# Altium schematic sheet esp32-board.SchDoc
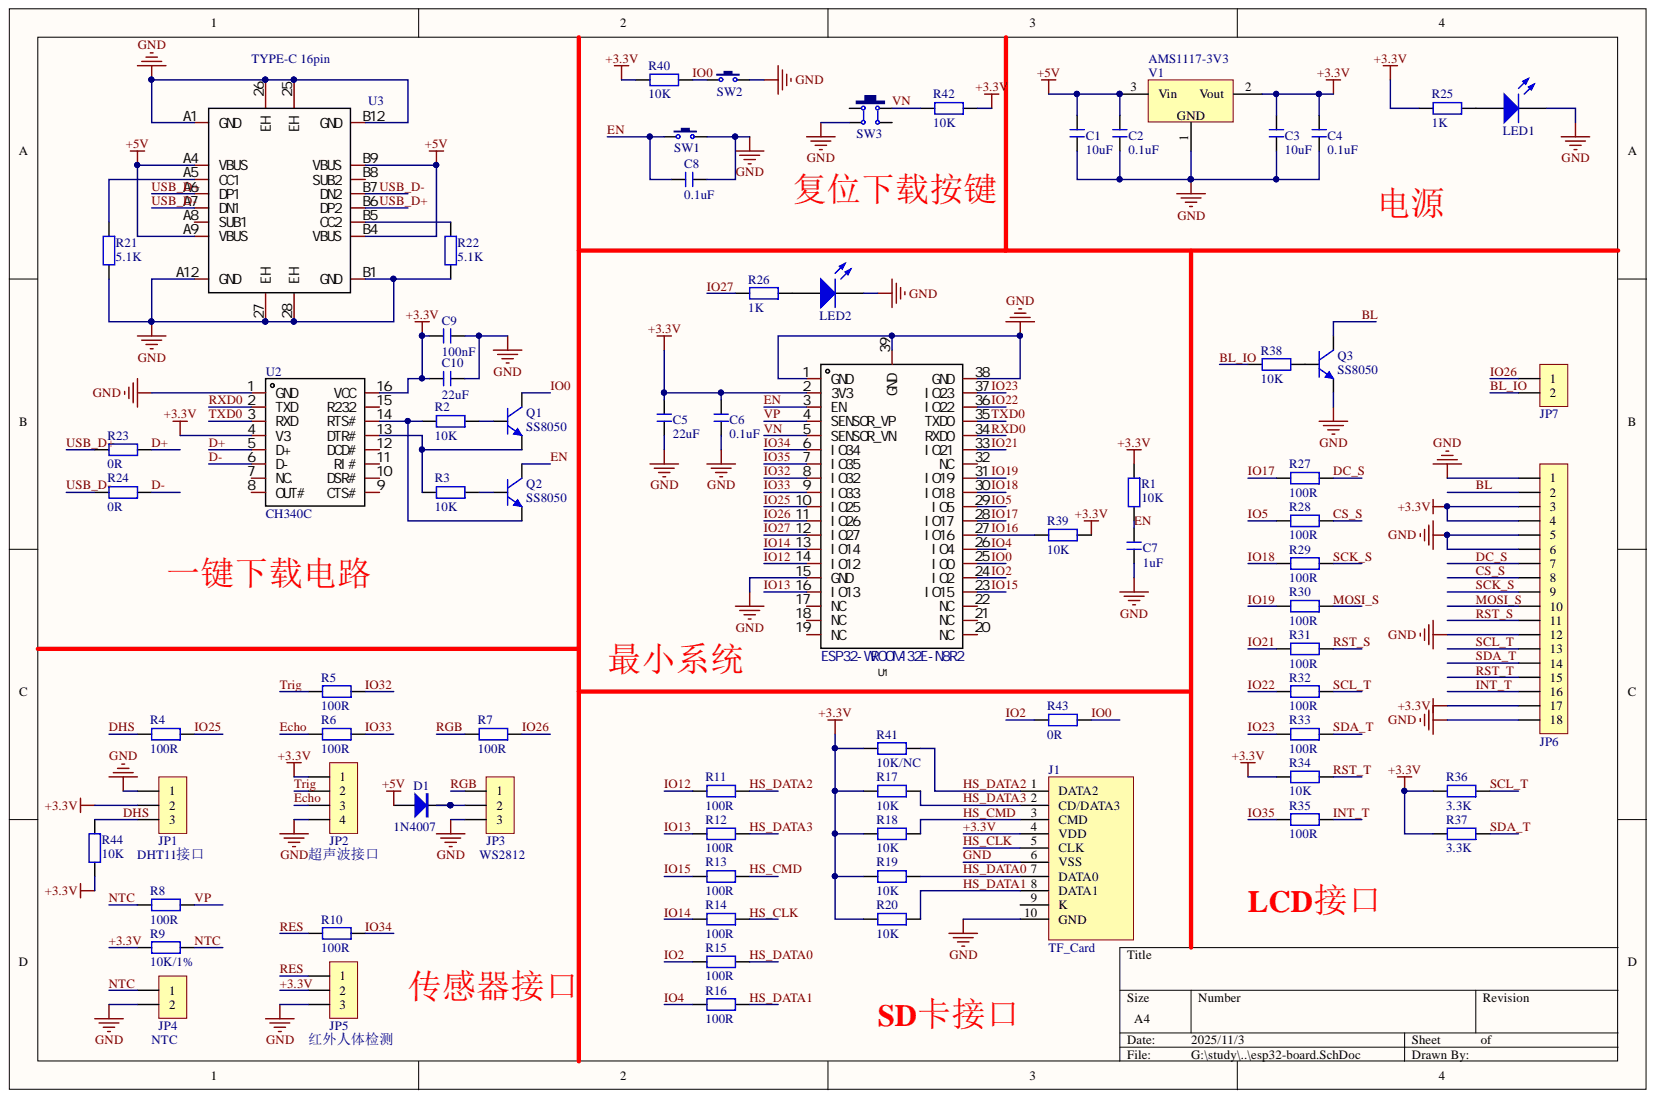
[diagram p0: esp32-board.SchDoc]

## Parts
C1  10uF  [C 0402_M]
C2  0.1uF  [C 0402_M]
C3  10uF  [C 0402_M]
C4  0.1uF  [C 0402_M]
C5  22uF  [C 0402_M]
C6  0.1uF  [C 0402_M]
C7  1uF  [C 0402_M]
C8  0.1uF  [C 0402_M]
C9  100nF  [C 0402_M]
C10  22uF  [C 0402_M]
D1  1N4007  [SOD-123]
J1  TF_Card  [Micro SD]
JP1  HDR-1X3  [HDR2.54-LI-3P]  (value not drawn)
JP2  HDR-1X4  [HDR2.54-LI-4P]  (value not drawn)
JP3  HDR-1X3  [HDR2.54-LI-3P]  (value not drawn)
JP4  HDR-1X2  [XH2.54-WI-2P]  (value not drawn)
JP5  HDR-1X3  [HDR2.54-LI-3P]  (value not drawn)
JP6  FPC0.5-18P 上接  [FPC0.5 2H-WS-18P]  (value not drawn)
JP7  HDR-1X2  [HDR2.54-LI-2P]  (value not drawn)
LED1  LED  [LED 0603R]
LED2  LED  [LED 0603B]
Q1  SS8050  [SOT23-3L]
Q2  SS8050  [SOT23-3L]
Q3  SS8050  [SOT23-3N]
R1  10K  [R 0402_L]
R2  10K  [R 0402_L]
R3  10K  [R 0402_L]
R4  100R  [R 0402_L]
R5  100R  [R 0402_L]
R6  100R  [R 0402_L]
R7  100R  [R 0402_L]
R8  100R  [R 0402_L]
R9  10K/1%  [R 0603_L]
R10  100R  [R 0402_L]
R11  100R  [R 0402_L]
R12  100R  [R 0402_L]
R13  100R  [R 0402_L]
R14  100R  [R 0402_L]
R15  100R  [R 0402_L]
R16  100R  [R 0402_L]
R17  10K  [R 0402_L]
R18  10K  [R 0402_L]
R19  10K  [R 0402_L]
R20  10K  [R 0402_L]
R21  5.1K  [R 0402_L]
R22  5.1K  [R 0402_L]
R23  0R  [R 0402_L]
R24  0R  [R 0402_L]
R25  1K  [R 0402_L]
R26  1K  [R 0402_L]
R27  100R  [R 0402_L]
R28  100R  [R 0402_L]
R29  100R  [R 0402_L]
R30  100R  [R 0402_L]
R31  100R  [R 0402_L]
R32  100R  [R 0402_L]
R33  100R  [R 0402_L]
R34  10K  [R 0402_L]
R35  100R  [R 0402_L]
R36  3.3K  [R 0402_L]
R37  3.3K  [R 0402_L]
R38  10K  [R 0402_L]
R39  10K  [R 0402_L]
R40  10K  [R 0402_L]
R41  10K/NC  [R 0402_L]
R42  10K  [R 0402_L]
R43  0R  [R 0402_L]
R44  10K  [R 0402_L]
SW1  3x6x5  [TSW SMD-3*6*5]  (value not drawn)
SW2  3x6x5  [TSW SMD-3*6*5]  (value not drawn)
SW3  6x6x5  [TSW SMD-6*6*5]  (value not drawn)
U1  ESP32-WROOM-32E-N8R2  [WIFI-SMD_ESP32-WROOM-32E]
U2  CH340C  [SOP-16_L10.0-W3.9-P1.27-LS6.0-BL]
U3  TYPE-C 16pin  [USB Type-C-16Pin_A]
V1  AMS1117-3V3  [SOT223_L]

## Nets
+5V: C1.2 C2.2 D1.1 U3.A4(VBUS) U3.A9(VBUS) U3.B4(VBUS) U3.B9(VBUS) V1.3
+3.3V: C3.2 C4.2 C5.2 C6.2 C9.1 C10.1 J1.4(VDD) JP1.2 JP2.1 JP5.2 JP6.3 JP6.4 JP6.17 R1.2 R9.1 R17.1 R18.1 R19.1 R20.1 R25.1 R34.1 R36.1 R37.1 R39.2 R40.1 R41.1 R42.2 R44.1 U1.2(3V3) U2.4(V3) U2.16(VCC) V1.2
BL: JP6.2 Q3.3
BL_IO: JP7.2 R38.1
CS_S: JP6.8 R28.2
D+: R23.1 U2.5(D+)
D-: R24.1 U2.6(D-)
DC_S: JP6.7 R27.2
DHS: JP1.3 R4.1 R44.2
Echo: JP2.3 R6.1
EN: C7.2 C8.2 Q2.3 R1.1 SW1.1 U1.3(EN)
GND: C1.1 C2.1 C3.1 C4.1 C5.1 C6.1 C7.1 C8.1 C9.2 C10.2 J1.6(VSS) J1.10(GND) JP1.1 JP2.4 JP3.3 JP4.2 JP5.3 JP6.1 JP6.5 JP6.6 JP6.12 JP6.18 LED1.2 LED2.2 Q3.2 R21.1 R22.1 SW1.2 SW2.2 SW3.4 U1.1(GND) U1.15(GND) U1.38(GND) U1.39(GND) U2.1(GND) U3.25(EH) U3.26(EH) U3.27(EH) U3.28(EH) U3.A1(GND) U3.A12(GND) U3.B1(GND) U3.B12(GND) V1.1
HS_CLK: J1.5(CLK) R14.2
HS_CMD: J1.3(CMD) R13.2 R18.2
HS_DATA0: J1.7(DATA0) R15.2 R19.2
HS_DATA1: J1.8(DATA1) R16.2 R20.2
HS_DATA2: J1.1(DATA2) R11.2 R41.2
HS_DATA3: J1.2(CD/DATA3) R12.2 R17.2
INT_T: JP6.16 R35.2
IO0: Q1.3 R40.2 R43.2 SW2.1 U1.25(IO0)
IO2: R15.1 R43.1 U1.24(IO2)
IO4: R16.1 U1.26(IO4)
IO5: R28.1 U1.29(IO5)
IO12: R11.1 U1.14(IO12)
IO13: R12.1 U1.16(IO13)
IO14: R14.1 U1.13(IO14)
IO15: R13.1 U1.23(IO15)
IO16: R39.1 U1.27(IO16)
IO17: R27.1 U1.28(IO17)
IO18: R29.1 U1.30(IO18)
IO19: R30.1 U1.31(IO19)
IO21: R31.1 U1.33(IO21)
IO22: R32.1 U1.36(IO22)
IO23: R33.1 U1.37(IO23)
IO25: R4.2 U1.10(IO25)
IO26: JP7.1 R7.2 U1.11(IO26)
IO27: R26.1 U1.12(IO27)
IO32: R5.2 U1.8(IO32)
IO33: R6.2 U1.9(IO33)
IO34: R10.2 U1.6(IO34)
IO35: R35.1 U1.7(IO35)
MOSI_S: JP6.10 R30.2
NTC: JP4.1 R8.1 R9.2
RES: JP5.1 R10.1
RGB: JP3.1 R7.1
RST_S: JP6.11 R31.2
RST_T: JP6.15 R34.2
RXD0: U1.34(RXD0) U2.2(TXD)
SCK_S: JP6.9 R29.2
SCL_T: JP6.13 R32.2 R36.2
SDA_T: JP6.14 R33.2 R37.2
Trig: JP2.2 R5.1
TXD0: U1.35(TXD0) U2.3(RXD)
USB_D+: R23.2 U3.A6(DP1) U3.B6(DP2)
USB_D-: R24.2 U3.A7(DN1) U3.B7(DN2)
VN: R42.1 SW3.2 U1.5(SENSOR_VN)
VP: R8.2 U1.4(SENSOR_VP)
NetD1_2: D1.2 JP3.2
NetLED1_1: LED1.1 R25.2
NetLED2_1: LED2.1 R26.2
NetQ1_1: Q1.1 R2.2
NetQ1_2: Q1.2 R3.1 U2.13(DTR#)
NetQ2_1: Q2.1 R3.2
NetQ2_2: Q2.2 R2.1 U2.14(RTS#)
NetQ3_1: Q3.1 R38.2
NetR21_2: R21.2 U3.A5(CC1)
NetR22_2: R22.2 U3.B5(CC2)

Not connected: J1 9(K); SW3 1 3; U1 17(NC) 18(NC) 19(NC) 20(NC) 21(NC) 22(NC) 32(NC); U2 7(NC.) 8(OUT#) 9(CTS#) 10(DSR#) 11(RI#) 12(DCD#) 15(R232); U3 A8(SUB1) B8(SUB2)

## Library data of the parts
C1-C10 = C: 贴片电容; BOM名称: 无极性贴片电容; 淘宝ID: 封装库来自淘宝 ID：黄晶宝石; Component Kind: Standard; Library Name: 0.1 - 电阻-电容-电感.SchLib; 唯一出售店铺网址: https://shop463601444.taobao.com; 联系售后，技术支持: https://shop463601444.taobao.com
D1 = 1N4007: 整流二极管; 封装库来自淘宝卖家 ID：黄晶宝石: 其他店铺购入均为盗版，不享受售后技术支持服务，请立即申请退款; 淘宝ID: 封装库来自淘宝 ID：黄晶宝石; BOM名称: 整流二极管; Component Kind: Standard; Library Name: 0.2 - 二极管-整流桥.SchLib; 联系售后，技术支持: https://shop463601444.taobao.com
J1 = TF_Card: TF卡座; 版权归属: 源创客; BOM名称: TF卡座; Component Kind: Standard; Library Name: 4.1   - 接口类.SchLib; 学习资源: www.ooocke.com
JP1 JP3 JP5 = Header 3: 3P接插件; 版权归属: 封装库来自淘宝 ID：黄晶宝石; BOM名称: 3P接插件; Component Kind: Standard; Library Name: 5.4 - 接插件-脚距2.54 (100mil) .SchLib; 学习资源: https://shop463601444.taobao.com
JP2 = Header 4: 4P接插件; 版权归属: 封装库来自淘宝 ID：黄晶宝石; BOM名称: 4P接插件; Component Kind: Standard; Library Name: 5.4 - 接插件-脚距2.54 (100mil) .SchLib; 学习资源: https://shop463601444.taobao.com
JP4 JP7 = Header 2: 2P接插件; 版权归属: 封装库来自淘宝 ID：黄晶宝石; BOM名称: 2P接插件; Component Kind: Standard; Library Name: 5.4 - 接插件-脚距2.54 (100mil) .SchLib; 联系售后: https://shop463601444.taobao.com
JP6 = FPC-18P: FPC连接器; 版权归属: 源创客; BOM名称: FPC连接器; Component Kind: Standard; Library Name: 4.15 - FPC连接器.SchLib; 学习资源: www.ooocke.com
LED1 LED2 = LED: 发光二极管; Component Kind: Standard; Library Name: 0.3 - LED发光二极管.SchLib; 技术支持，联系售后: https://shop463601444.taobao.com
Q1-Q3 = 8050-SMD: 高频放大-NPN型; 属性: 40V 1.5A 1W 100MHZ; 版权归属: 封装库来自淘宝 ID：黄晶宝石; BOM名称: 高频放大-NPN型; Component Kind: Standard; Library Name: 0.4 - 三极管.SchLib; 联系售后: https://shop463601444.taobao.com
R1-R44 = R: 贴片电阻; 封装库来自淘宝卖家 ID：黄晶宝石: 其他店铺购入均为盗版，不享受售后技术支持服务，请立即申请退款; 版权归属: 来自淘宝 ID：黄晶宝石; BOM名称: 贴片电阻; Component Kind: Standard; Library Name: 0.1 - 电阻-电容-电感.SchLib; 联系售后: https://shop463601444.taobao.com
SW1 SW2 = TSW 3x6: 3x6轻触开关; 版权归属: 封装库来自淘宝 ID：黄晶宝石; BOM名称: 3x6轻触开关; Component Kind: Standard; Library Name: 0.7 - 常用按键-开关.SchLib; 学习资源: https://shop463601444.taobao.com
SW3 = TSW SMD-6x6: 贴片6x6轻触开关; 版权归属: 源创客; BOM名称: 贴片6x6轻触开关; Component Kind: Standard; Library Name: 5.1   - 按键-开关.SchLib; 学习资源: www.ooocke.com
U1 = ESP32-WROOM-32E-N8R2: Manufacturer: ESPRESSIF(乐鑫); Add into BOM: yes; Component Kind: Standard; Convert to PCB: yes; Datasheet: https://atta.szlcsc.com/upload/public/pdf/source/20230406/585EC4AF7B044F74CF013F41315A1226.pdf; Device: ESP32-WROOM-32E-N8R2; JLCPCB Part Class: Extended Part; LCSC Part Name: 通用型Wi-Fi+BT+BLE MCU模组; Library Name: ESP32-WROOM-32E-N8R21_ESP32-WROOM-32E-N8R2.SCHLIB; Manufacturer Part: ESP32-WROOM-32E-N8R2; Supplier: LCSC; Supplier Footprint: LCC-38(18x25.5); Supplier Part: C5361945; Symbol: ESP32-WROOM-32E-N8R2
V1 = AMS1117: 三端稳压芯片; BOM名称: 稳压芯片; 淘宝ID: 封装库来自淘宝 ID：黄晶宝石; Component Kind: Standard; Library Name: 1.1 - 电源类芯片.SchLib; 欢迎光临淘宝店铺: https://shop463601444.taobao.com
U2 = CH340C: Component Kind: Standard; Description: CH340C; Library Name: 1.9 - CH340系列编程器芯片.SchLib
U3 = TYPE-C 16pin: Component Kind: Standard; Library Name: 4.1   - 接口类.SchLib
